# Altium schematic sheet 04_Clock.SchDoc
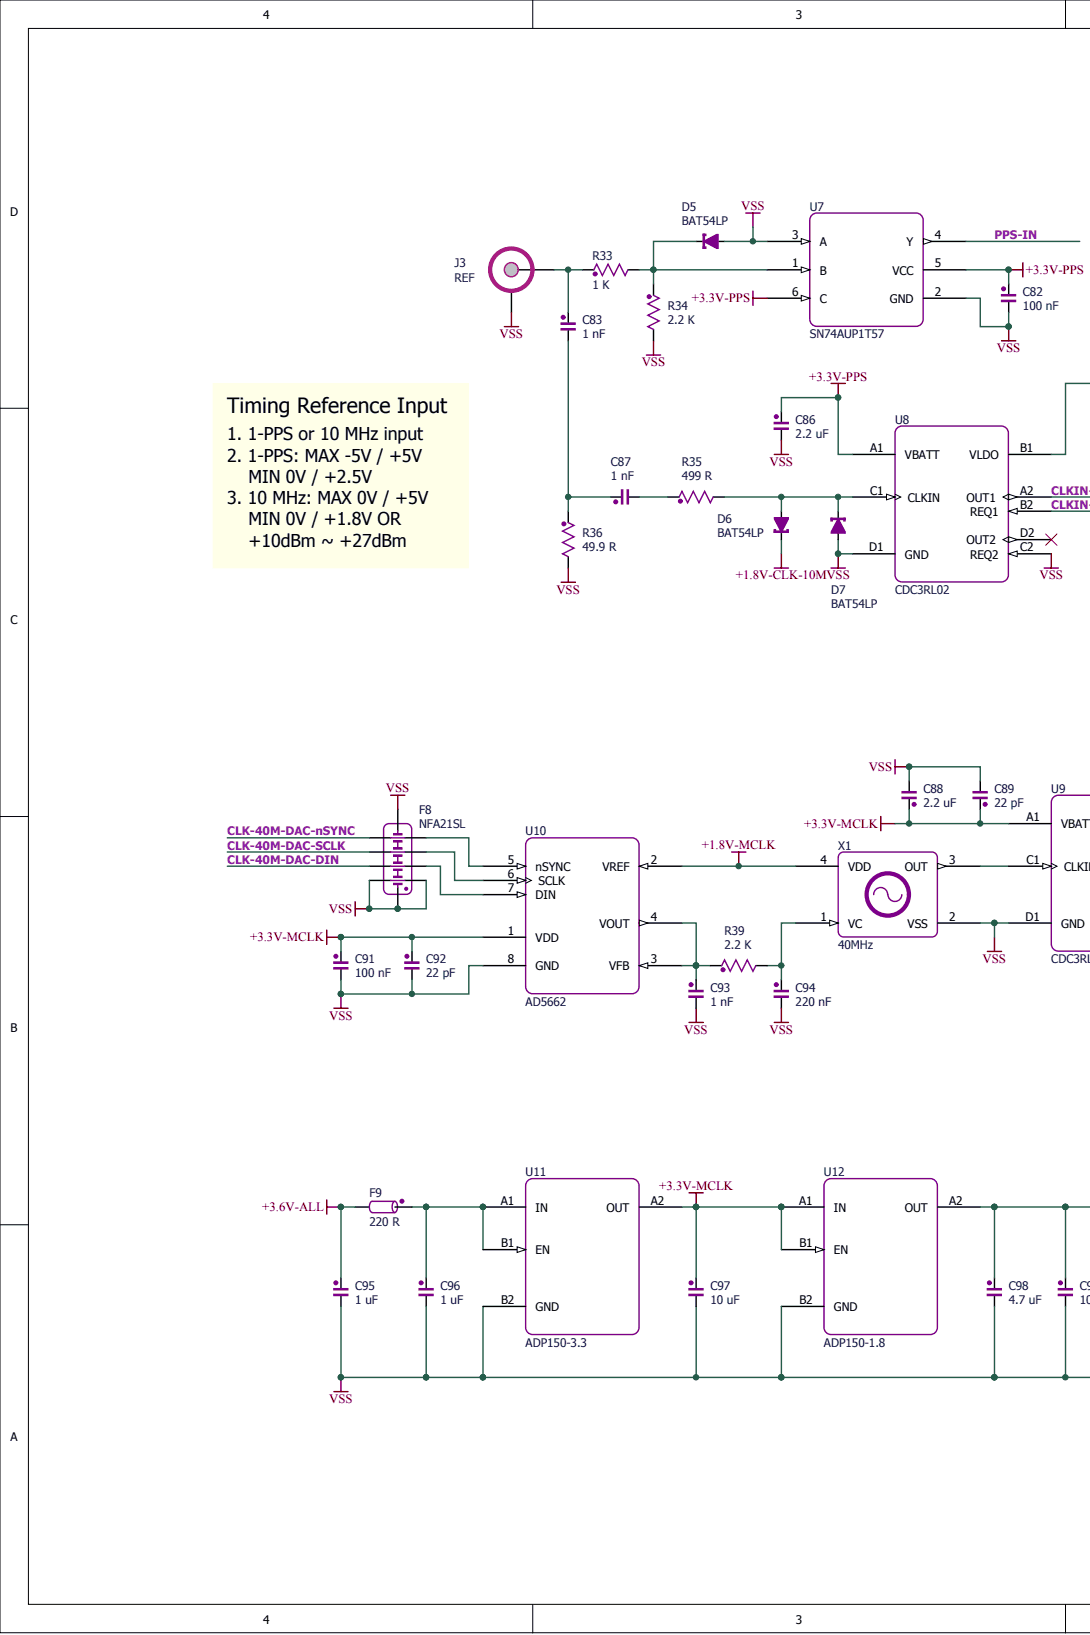
[diagram p0: 04_Clock.SchDoc, left]
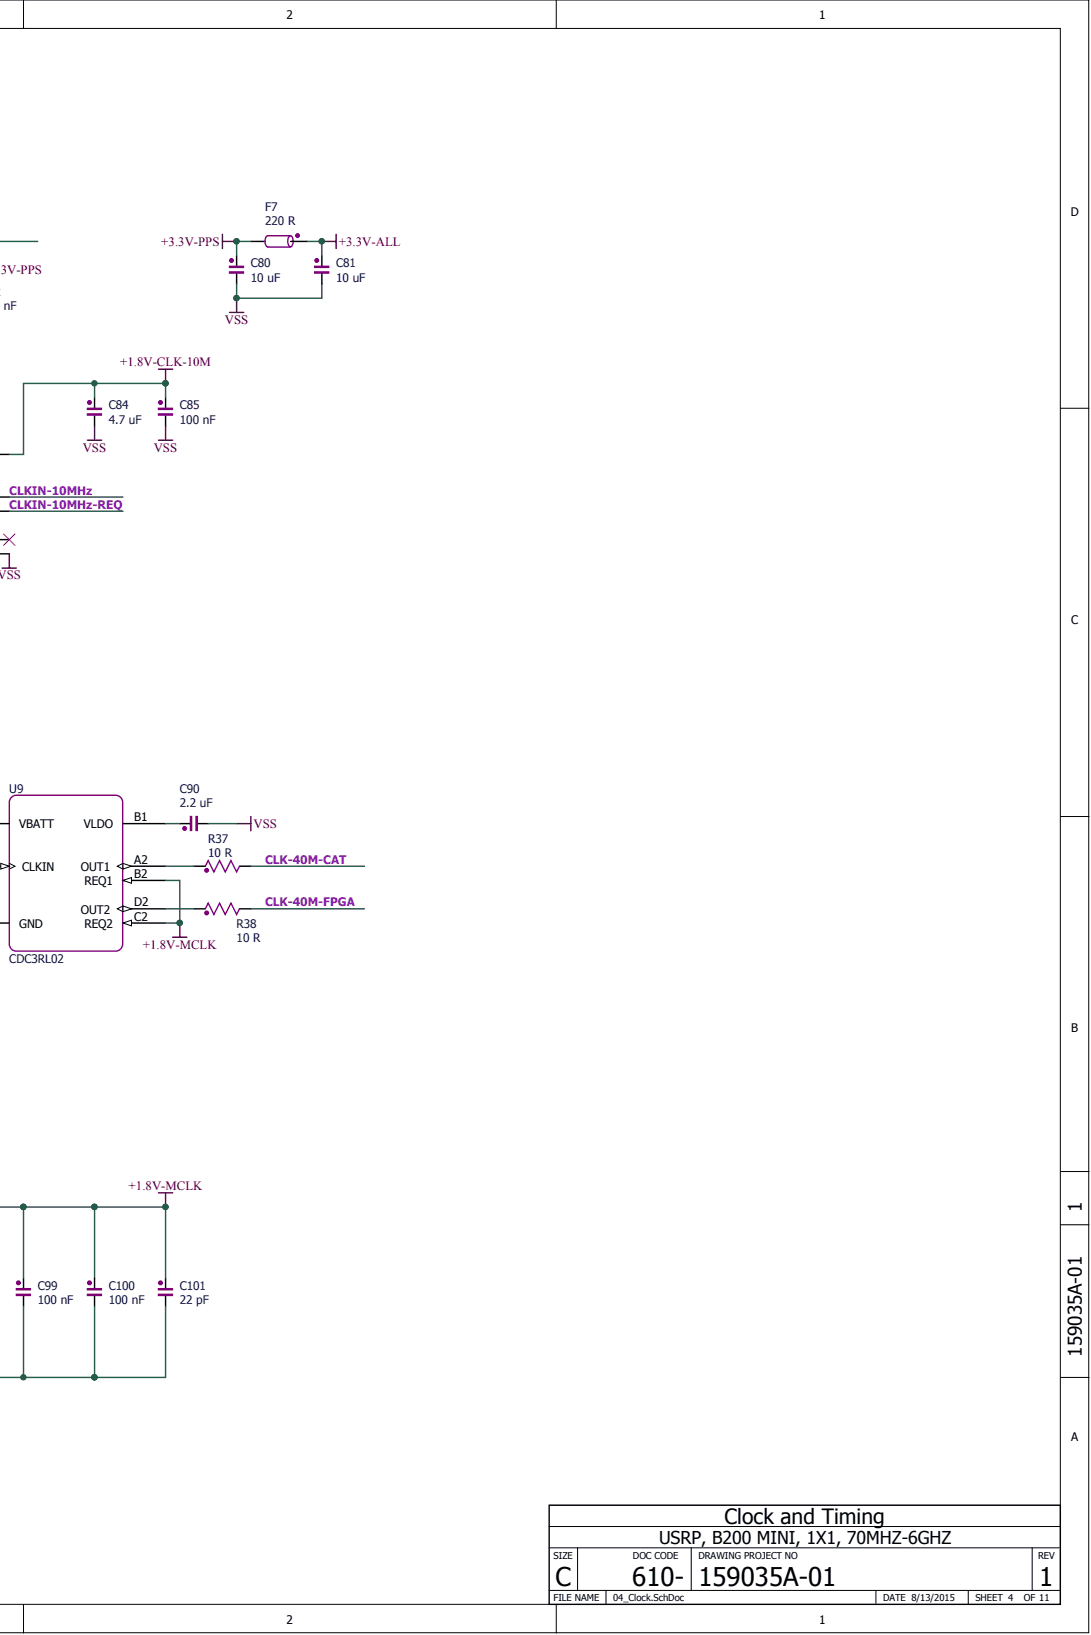
[diagram p1: 04_Clock.SchDoc, right]

## Parts
C80  10 uF  [0402-CAP]
C81  10 uF  [0402-CAP]
C82  100 nF  [0201-CAP]
C83  1 nF  [0201-CAP]
C84  4.7 uF  [0402-CAP]
C85  100 nF  [0201-CAP]
C86  2.2 uF  [0201-CAP]
C87  1 nF  [0201-CAP]
C88  2.2 uF  [0201-CAP]
C89  22 pF  [0201-CAP-RF]
C90  2.2 uF  [0201-CAP]
C91  100 nF  [0201-CAP]
C92  22 pF  [0201-CAP-RF]
C93  1 nF  [0201-CAP]
C94  220 nF  [0201-CAP]
C95  1 uF  [0402-CAP]
C96  1 uF  [0402-CAP]
C97  10 uF  [0402-CAP]
C98  4.7 uF  [0402-CAP]
C99  100 nF  [0201-CAP]
C100  100 nF  [0201-CAP]
C101  22 pF  [0201-CAP-RF]
D5  BAT54LP  [X1-DFN1006-2]
D6  BAT54LP  [X1-DFN1006-2]
D7  BAT54LP  [X1-DFN1006-2]
F7  220 R  [0402-BEAD]
F8  NFA21SL  [0402-NF-4]
F9  220 R  [0402-BEAD]
J3  REF  [142-0771-821]
R33  1 K  [0201-RES]
R34  2.2 K  [0201-RES]
R35  499 R  [0201-RES]
R36  49.9 R  [0201-RES]
R37  10 R  [0201-RES]
R38  10 R  [0201-RES]
R39  2.2 K  [0201-RES]
U7  SN74AUP1T57  [SON-6-DRY]
U8  CDC3RL02  [TI-VFP-8]
U9  CDC3RL02  [TI-VFP-8]
U10  AD5662  [ADI-RJ-8]
U11  ADP150-3.3  [ADI-WLCSP-4]
U12  ADP150-1.8  [ADI-WLCSP-4]
X1  40MHz  [CTS-520]

## Nets
+1.8V-CLK-10M: C84.2 C85.2 D6.1 U8.B1(VLDO)
+1.8V-MCLK: C98.2 C99.2 C100.2 C101.2 U9.B2(REQ1) U9.C2(REQ2) U10.2(VREF) U12.A2(OUT) X1.4(VDD)
+3.3V-ALL: C81.2 F7.2
+3.3V-MCLK: C88.2 C89.2 C91.2 C92.2 C97.2 U9.A1(VBATT) U10.1(VDD) U11.A2(OUT) U12.A1(IN) U12.B1(EN)
+3.3V-PPS: C80.2 C82.2 C86.2 F7.1 U7.5(VCC) U7.6(C) U8.A1(VBATT)
+3.6V-ALL: C95.2 F9.1
VSS: C80.1 C81.1 C82.1 C84.1 C85.1 C86.1 C88.1 C89.1 C90.1 C91.1 C92.1 C93.1 C94.1 C95.1 C96.1 C97.1 C98.1 C99.1 C100.1 C101.1 D5.2 D7.2 F8.1 F8.2 F8.9 F8.10 J3.2 R34.1 R36.1 U7.2(GND) U7.3(A) U8.C2(REQ2) U8.D1(GND) U9.D1(GND) U10.8(GND) U11.B2(GND) U12.B2(GND) X1.2(VSS)
CLK-40M-CAT: R37.1
CLK-40M-DAC-DIN: F8.4
CLK-40M-DAC-NSYNC: F8.8
CLK-40M-DAC-SCLK: F8.6
CLK-40M-FPGA: R38.1
CLKIN-10MHZ: U8.A2(OUT1)
CLKIN-10MHZ-REQ: U8.B2(REQ1)
PPS-IN: U7.4(Y)
NetC83_1: C83.1 C87.2 R36.2
NetC83_2: C83.2 J3.1 R33.2
NetC87_1: C87.1 R35.2
NetC90_2: C90.2 U9.B1(VLDO)
NetC93_2: C93.2 R39.2 U10.3(VFB) U10.4(VOUT)
NetC94_2: C94.2 R39.1 X1.1(VC)
NetC96_2: C96.2 F9.2 U11.A1(IN) U11.B1(EN)
NetD5_1: D5.1 R33.1 R34.2 U7.1(B)
NetD6_2: D6.2 D7.1 R35.1 U8.C1(CLKIN)
NetF8_3: F8.3 U10.7(DIN)
NetF8_5: F8.5 U10.6(SCLK)
NetF8_7: F8.7 U10.5(nSYNC)
NetR37_2: R37.2 U9.A2(OUT1)
NetR38_2: R38.2 U9.D2(OUT2)
NetU9_C1: U9.C1(CLKIN) X1.3(OUT)

Not connected: U8 D2(OUT2)

## Library data of the parts
C80 C81 C97 = CAP: CAP, 10uF, X5R, 6.3V, ±20%, 0402, PbF; PartNumber: C0402X5R6R3-106MNP; Designer: shyul; PCBMarker: Capacitor; PinCountHole: 0; PinCountSMD: 2; Vendor: VENKEL; Component Kind: Standard; SerialNumber: 0; Value: 10 uF; Version: 1.0; VersionDate: 04-27-2011; Library Name: Basc_1_Passive.IntLib; Publisher: Cowin
C82 C85 C91 C99 C100 = CAP: CAP,SM,0.1UF,16V,10%,CER,X5R,0201,H=.014IN; PartNumber: GRM033R61C104KE14D; Designer: shyul; PCBMarker: Capacitor; PinCountHole: 0; PinCountSMD: 2; SerialNumber: 717062-01; Vendor: MURATA; Component Kind: Standard; Value: 100 nF; Version: 1.0; VersionDate: 04-27-2011; Library Name: Basc_1_Passive.IntLib; Publisher: Cowin
C83 C87 C93 = CAP: CAP, 1nF, X7R, 10V, ±10%, 0201, PbF; PartNumber: C0201X7R100-102KNP; Designer: shyul; PCBMarker: Capacitor; PinCountHole: 0; PinCountSMD: 2; Vendor: VENKEL; Component Kind: Standard; SerialNumber: 0; Value: 1 nF; Version: 1.0; VersionDate: 04-27-2011; Library Name: Basc_1_Passive.IntLib; Publisher: Cowin
C84 C98 = CAP: CAP, 4.7uF, X5R, 6.3V, ±20%, 0402, PbF; PartNumber: GRM155R60J475ME47; Designer: shyul; PCBMarker: Capacitor; PinCountHole: 0; PinCountSMD: 2; Vendor: muRata; Component Kind: Standard; SerialNumber: 0; Value: 4.7 uF; Version: 1.0; VersionDate: 04-27-2011; Library Name: Basc_1_Passive.IntLib; Publisher: Cowin
C86 C88 C90 = CAP: CAP, 2.2uF, X5R, 6.3V, ±20%, 0201, PbF; PartNumber: C0201X5R6R3-225MNP; Designer: shyul; PCBMarker: Capacitor; PinCountHole: 0; PinCountSMD: 2; Vendor: VENKEL; Component Kind: Standard; Value: 2.2 uF; Version: 1.0; VersionDate: 04-27-2011; Library Name: Basc_1_Passive.IntLib; Publisher: Cowin
C89 C92 C101 = CAP: CAP, SM, 22PF, 25V, 5%, CER, C0G, 0201, H=.010IN; PartNumber: C0201C0G250-220JNP; Designer: shyul; PCBMarker: Capacitor; PinCountHole: 0; PinCountSMD: 2; SerialNumber: 716728-01; Vendor: VENKEL; Component Kind: Standard; Value: 22 pF; Version: 1.0; VersionDate: 04-27-2011; Library Name: Basc_1_Passive.IntLib; Publisher: Cowin
C94 = CAP: CAP, 220nF, X5R, 6.3V, ±10%, 0201, PbF; PartNumber: C0201X5R6R3-224KNP; Designer: shyul; PCBMarker: Capacitor; PinCountHole: 0; PinCountSMD: 2; Vendor: VENKEL; Component Kind: Standard; SerialNumber: 0; Value: 220 nF; Version: 1.0; VersionDate: 04-27-2011; Library Name: Basc_1_Passive.IntLib; Publisher: Cowin
C95 C96 = CAP: CAP, 1uF, X5R, 10V, ±10%, 0402, PbF; PartNumber: C0402X5R100-105KNP; Designer: shyul; PCBMarker: Capacitor; PinCountHole: 0; PinCountSMD: 2; Vendor: VENKEL; Component Kind: Standard; SerialNumber: 0; Value: 1 uF; Version: 1.0; VersionDate: 04-27-2011; Library Name: Basc_1_Passive.IntLib; Publisher: Cowin
D5-D7 = BAT54LP: SURFACE MOUNT SCHOTTKY BARRIER DIODE; PartNumber: BAT54LP-7; Designer: shyul; PCBMarker: Schottky Diode; PinCountHole: 0; PinCountSMD: 2; Vendor: Diodes; Component Kind: Standard; SerialNumber: 0; Value: BAT54LP; Version: 1.0; VersionDate: 04-27-2011; Library Name: Argon.SchLib; Publisher: Cowin
F7 F9 = BEAD: BEAD, 220R, 700mA, PbF; PartNumber: BLM15EG221SN1; Designer: shyul; PinCountHole: 0; PinCountSMD: 2; Vendor: muRata; Component Kind: Standard; PCBMarker: Bead; SerialNumber: 0; Value: 220 R; Version: 1.0; VersionDate: 04-28-2011; Library Name: Basc_2_EMI_Protection.IntLib; Publisher: Cowin
F8 = NFA_N4: EMI RC Filter Array x4; PartNumber: NFA21SL806X1A48L; Designer: shyul; PinCountHole: 0; PinCountSMD: 10; Vendor: muRata; Component Kind: Standard; PCBMarker: EMI; SerialNumber: 0; Value: NFA21SL; Version: 1.0; VersionDate: 04-27-2011; Library Name: Basc_2_EMI_Protection.IntLib; Publisher: Cowin
J3 = SMA_JACK: CONN, SMA, JACK, END LAUNCH, PCB MOUNT, H=.134IN; Designer: shyul; PCBMarker: RF Connector; PinCountHole: 2; PinCountSMD: 3; SerialNumber: 768587-01; Vendor: Emerson; Component Kind: Standard; Library Name: Connector_3_Analog_RF.IntLib; PartNumber: 142-0771-821; Value: REF; Version: 1.0; VersionDate: 05-18-2011; Publisher: Cowin
R33 = RES: RES, 1K, ±5%, 1/20W, 0201, PbF; PartNumber: CR0201-20W-102JT; Designer: shyul; PCBMarker: Resistor; PinCountHole: 0; PinCountSMD: 2; Vendor: VENKEL; Component Kind: Standard; SerialNumber: 0; Value: 1 K; Version: 1.0; VersionDate: 04-27-2011; Library Name: Basc_1_Passive.IntLib; Publisher: Cowin
R34 R39 = RES: RES, 2.2K, ±5%, 1/20W, 0201, PbF; PartNumber: CR0201-20W-222JT; Designer: shyul; PCBMarker: Resistor; PinCountHole: 0; PinCountSMD: 2; Vendor: VENKEL; Component Kind: Standard; SerialNumber: 0; Value: 2.2 K; Version: 1.0; VersionDate: 04-27-2011; Library Name: Basc_1_Passive.IntLib; Publisher: Cowin
R35 = RES: RES, 499R, ±1%, 1/20W, 0201, PbF; PartNumber: CR0201-20W-4990FT; Designer: shyul; PCBMarker: Resistor; PinCountHole: 0; PinCountSMD: 2; Vendor: VENKEL; Component Kind: Standard; SerialNumber: 0; Value: 499 R; Version: 1.0; VersionDate: 04-27-2011; Library Name: Basc_1_Passive.IntLib; Publisher: Cowin
R36 = RES: RES,SM,49.9,1/20W,1%,THICK FILM,0201,H=.012IN; PartNumber: CRCW020149R9FNED; Designer: shyul; PCBMarker: Resistor; PinCountHole: 0; PinCountSMD: 2; SerialNumber: 714206-01; Vendor: VISHAY-DALE; Component Kind: Standard; Value: 49.9 R; Version: 1.0; VersionDate: 04-27-2011; Library Name: Basc_1_Passive.IntLib; Publisher: Cowin
R37 R38 = RES: RES, 10R, ±1%, 1/20W, 0201, PbF; PartNumber: CR0201-20W-10R0FT; Designer: shyul; PCBMarker: Resistor; PinCountHole: 0; PinCountSMD: 2; Vendor: VENKEL; Component Kind: Standard; SerialNumber: 0; Value: 10 R; Version: 1.0; VersionDate: 04-27-2011; Library Name: Basc_1_Passive.IntLib; Publisher: Cowin
U7 = SN74AUP1T57: Single-Supply Voltage-Level Translator; PartNumber: SN74AUP1T57DRYR; Designer: shyul; PCBMarker: Logic; PinCountHole: 0; PinCountSMD: 6; Vendor: TI; Component Kind: Standard; SerialNumber: 0; Value: SN74AUP1T57; Version: 1.0; VersionDate: 10-16-2014; Library Name: Argon.SchLib; Publisher: Shyu Lee
U8 U9 = CDC3RL02: LOW PHASE-NOISE TWO-CHANNEL CLOCK FAN-OUT BUFFER; PartNumber: CDC3RL02YFPR; Designer: shyul; PCBMarker: Logic; PinCountHole: 0; PinCountSMD: 8; Vendor: TI; Component Kind: Standard; SerialNumber: 0; Value: CDC3RL02; Version: 1.0; VersionDate: 10-16-2014; Library Name: Argon.SchLib; Publisher: Shyu Lee
U10 = AD5662: 3.3 V and 2.5 V LVCMOS High-Performance Clock Buffer; PartNumber: AD5662ARJZ-2REEL7; Designer: shyul; PCBMarker: Logic; PinCountHole: 0; PinCountSMD: 8; Vendor: ADI; Component Kind: Standard; SerialNumber: 0; Value: AD5662; Version: 1.0; VersionDate: 10-23-2014; Library Name: Argon.SchLib; Publisher: Shyu Lee
U11 = ADP150: Ultralow Noise 150 mA CMOS Linear Regulator; PartNumber: ADP150ACBZ-3.3-R7; Designer: shyul; PCBMarker: Power; PinCountHole: 0; PinCountSMD: 4; Vendor: ADI; Component Kind: Standard; SerialNumber: 0; Value: ADP150-3.3; Version: 1.0; VersionDate: 01-07-2015; Library Name: Argon.SchLib; Publisher: Shyu Lee
U12 = ADP150: Ultralow Noise 150 mA CMOS Linear Regulator; PartNumber: ADP150ACBZ-1.8-R7; Designer: shyul; PCBMarker: Power; PinCountHole: 0; PinCountSMD: 4; Vendor: ADI; Component Kind: Standard; SerialNumber: 0; Value: ADP150-1.8; Version: 1.0; VersionDate: 01-07-2015; Library Name: Argon.SchLib; Publisher: Shyu Lee
X1 = CTS-520: CTS Type 520 VCTCXO, 1.8V, 40MHz, ±0.5ppm; PartNumber: 520M05IA40M0000; Designer: shyul; PCBMarker: Crystal; PinCountHole: 0; PinCountSMD: 4; Component Kind: Standard; SerialNumber: 0; Value: 40MHz; Vendor: CTS; Version: 1.0; VersionDate: 09-26-2014; Library Name: Argon.SchLib; Publisher: Cowin
